# Altium schematic sheet IO-LINK TRANSCEIVER.SchDoc("IO-LINK TRANSCEIVER")
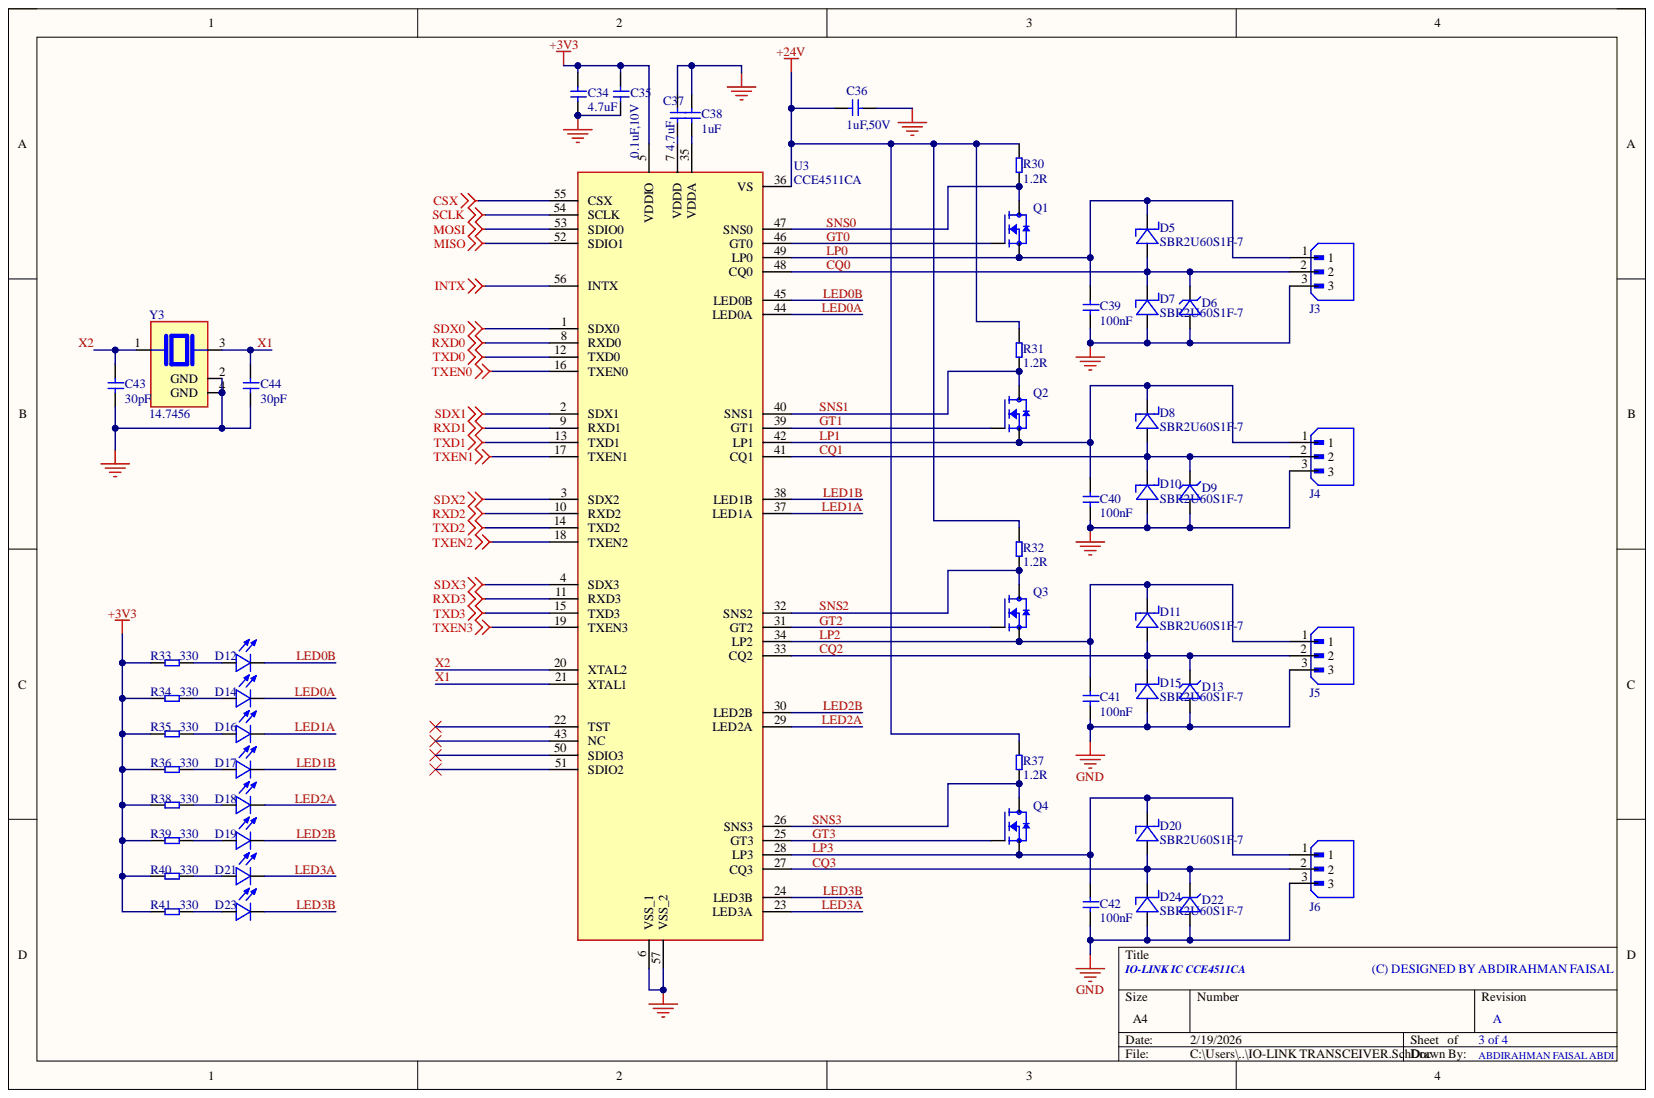
[diagram p0: IO-LINK TRANSCEIVER.SchDoc]

## Parts
C34  4.7uF  [C0603]
C35  0.1uF,10V  [C0603]
C36  1uF,50V  [C0603]
C37  4.7uF  [C0603]
C38  1uF  [C0603]
C39  100nF  [C0603]
C40  100nF  [C0603]
C41  100nF  [C0603]
C42  100nF  [C0603]
C43  30pF  [C0603]
C44  30pF  [C0603]
D5  SBR2U60S1F-7  [SBR2U60S1F-7]
D6  SMF4L33A  [SODFL3718X108N]  (value not drawn)
D7  SBR2U60S1F-7  [SBR2U60S1F-7]
D8  SBR2U60S1F-7  [SBR2U60S1F-7]
D9  SMF4L33A  [SODFL3718X108N]  (value not drawn)
D10  SBR2U60S1F-7  [SBR2U60S1F-7]
D11  SBR2U60S1F-7  [SBR2U60S1F-7]
D12  RED  [LED_VLMS1300-GS08]  (value not drawn)
D13  SMF4L33A  [SODFL3718X108N]  (value not drawn)
D14  RED  [LED_VLMS1300-GS08]  (value not drawn)
D15  SBR2U60S1F-7  [SBR2U60S1F-7]
D16  RED  [LED_VLMS1300-GS08]  (value not drawn)
D17  RED  [LED_VLMS1300-GS08]  (value not drawn)
D18  RED  [LED_VLMS1300-GS08]  (value not drawn)
D19  RED  [LED_VLMS1300-GS08]  (value not drawn)
D20  SBR2U60S1F-7  [SBR2U60S1F-7]
D21  RED  [LED_VLMS1300-GS08]  (value not drawn)
D22  SMF4L33A  [SODFL3718X108N]  (value not drawn)
D23  RED  [LED_VLMS1300-GS08]  (value not drawn)
D24  SBR2U60S1F-7  [SBR2U60S1F-7]
J3  B3B-XH-A(LF)(SN)  [JST_B3B-XH-A]  (value not drawn)
J4  B3B-XH-A(LF)(SN)  [JST_B3B-XH-A]  (value not drawn)
J5  B3B-XH-A(LF)(SN)  [JST_B3B-XH-A]  (value not drawn)
J6  B3B-XH-A(LF)(SN)  [JST_B3B-XH-A]  (value not drawn)
Q1  FQT7N10LTF  [SOT230P700X180-4N]  (value not drawn)
Q2  FQT7N10LTF  [SOT230P700X180-4N]  (value not drawn)
Q3  FQT7N10LTF  [SOT230P700X180-4N]  (value not drawn)
Q4  FQT7N10LTF  [SOT230P700X180-4N]  (value not drawn)
R30  1.2R  [R0805]
R31  1.2R  [R0805]
R32  1.2R  [R0805]
R33  330  [R0603]
R34  330  [R0603]
R35  330  [R0603]
R36  330  [R0603]
R37  1.2R  [R0805]
R38  330  [R0603]
R39  330  [R0603]
R40  330  [R0603]
R41  330  [R0603]
U3  CCE4511CA  [CCE4511CA]
Y3  14.7456  [XTAL_ABM8-14.7456MHz-12-D1X-T]

## Nets
+3V3: C34.2 C35.2 R33.1 R34.1 R35.1 R36.1 R38.1 R39.1 R40.1 R41.1 U3.5(VDDIO)
+24V: C36.2 R30.2 R31.2 R32.2 R37.2 U3.36(VS)
CSX: U3.55(CSX)
GND: C34.1 C35.1 C36.1 C37.2 C38.2 C39.1 C40.1 C41.1 C42.1 C43.1 C44.1 D6.2 D7.2 D9.2 D10.2 D13.2 D15.2 D22.2 D24.2 J3.3 J4.3 J5.3 J6.3 U3.6(VSS_1) U3.57(VSS_2) Y3.2(GND) Y3.4(GND)
INTX: U3.56(INTX)
MISO: U3.52(SDIO1)
MOSI: U3.53(SDIO0)
RXD0: U3.8(RXD0)
RXD1: U3.9(RXD1)
RXD2: U3.10(RXD2)
RXD3: U3.11(RXD3)
SCLK: U3.54(SCLK)
SDX0: U3.1(SDX0)
SDX1: U3.2(SDX1)
SDX2: U3.3(SDX2)
SDX3: U3.4(SDX3)
TXD0: U3.12(TXD0)
TXD1: U3.13(TXD1)
TXD2: U3.14(TXD2)
TXD3: U3.15(TXD3)
TXEN0: U3.16(TXEN0)
TXEN1: U3.17(TXEN1)
TXEN2: U3.18(TXEN2)
TXEN3: U3.19(TXEN3)
CQ0: D5.2 D6.1 D7.1 J3.2 U3.48(CQ0)
CQ1: D8.2 D9.1 D10.1 J4.2 U3.41(CQ1)
CQ2: D11.2 D13.1 D15.1 J5.2 U3.33(CQ2)
CQ3: D20.2 D22.1 D24.1 J6.2 U3.27(CQ3)
GT0: Q1.1 U3.46(GT0)
GT1: Q2.1 U3.39(GT1)
GT2: Q3.1 U3.31(GT2)
GT3: Q4.1 U3.25(GT3)
LED0A: D14.C U3.44(LED0A)
LED0B: D12.C U3.45(LED0B)
LED1A: D16.C U3.37(LED1A)
LED1B: D17.C U3.38(LED1B)
LED2A: D18.C U3.29(LED2A)
LED2B: D19.C U3.30(LED2B)
LED3A: D21.C U3.23(LED3A)
LED3B: D23.C U3.24(LED3B)
LP0: C39.2 D5.1 J3.1 Q1.3 U3.49(LP0)
LP1: C40.2 D8.1 J4.1 Q2.3 U3.42(LP1)
LP2: C41.2 D11.1 J5.1 Q3.3 U3.34(LP2)
LP3: C42.2 D20.1 J6.1 Q4.3 U3.28(LP3)
SNS0: Q1.4 R30.1 U3.47(SNS0)
SNS1: Q2.4 R31.1 U3.40(SNS1)
SNS2: Q3.4 R32.1 U3.32(SNS2)
SNS3: Q4.4 R37.1 U3.26(SNS3)
X1: C44.2 U3.21(XTAL1) Y3.3
X2: C43.2 U3.20(XTAL2) Y3.1
NetC37_1: C37.1 U3.7(VDDD)
NetC38_1: C38.1 U3.35(VDDA)
NetD12_A: D12.A R33.2
NetD14_A: D14.A R34.2
NetD16_A: D16.A R35.2
NetD17_A: D17.A R36.2
NetD18_A: D18.A R38.2
NetD19_A: D19.A R39.2
NetD21_A: D21.A R40.2
NetD23_A: D23.A R41.2

Not connected: U3 22(TST) 43(NC) 50(SDIO3) 51(SDIO2)

## Library data of the parts
C34 C37 = GRM188Z71A475ME15D: 4.7 µF ±20% 10V Ceramic Capacitor X7R 0603 (1608 Metric); Manufacturer: Murata; Manufacturer Lifecycle Status: IN PRODUCTION; Value: 4.7µF; Case/Package: 0603; Category: Ceramic Capacitors; Component Kind: Standard; ComponentLink1Description: https://datasheet.octopart.com:443/GRM188Z71A475ME15D-Murata-datasheet-149523300.pdf?src-supplier=Newark; ComponentLink1URL: https://datasheet.octopart.com:443/GRM188Z71A475ME15D-Murata-datasheet-149523300.pdf?src-supplier=Newark; ComponentLink2Description: https://datasheet.octopart.com:443/GRM188Z71A475ME15D-Murata-datasheet-141276011.pdf?src-supplier=Geoflip; ComponentLink2URL: https://datasheet.octopart.com:443/GRM188Z71A475ME15D-Murata-datasheet-141276011.pdf?src-supplier=Geoflip; ComponentLink3Description: https://datasheet.octopart.com:443/GRM188Z71A475ME15D-Murata-datasheet-165579666.pdf?src-supplier=TME; ComponentLink3URL: https://datasheet.octopart.com:443/GRM188Z71A475ME15D-Murata-datasheet-165579666.pdf?src-supplier=TME; ComponentLink4Description: https://datasheet.octopart.com:443/GRM188Z71A475ME15D-Murata-datasheet-140705914.pdf?src-supplier=Ciiva; ComponentLink4URL: https://datasheet.octopart.com:443/GRM188Z71A475ME15D-Murata-datasheet-140705914.pdf?src-supplier=Ciiva; ComponentLink5Description: https://datasheet.octopart.com:443/GRM188Z71A475ME15D-Murata-datasheet-138743432.pdf?src-supplier=Ciiva; ComponentLink5URL: https://datasheet.octopart.com:443/GRM188Z71A475ME15D-Murata-datasheet-138743432.pdf?src-supplier=Ciiva; ComponentLink6Description: http://sigma.octopart.com:80/reach_statement/Murata-company-16.pdf?src-supplier=Compliance+Statements; ComponentLink6URL: http://sigma.octopart.com:80/reach_statement/Murata-company-16.pdf?src-supplier=Compliance+Statements; Dielectric Material: Ceramic; Height: 800µm; Length: 1.6mm; Lifecycle Status: Production; Manufacturer Part Number: GRM188Z71A475ME15D; Material: Ceramic; Max Operating Temperature: 125°C; Min Operating Temperature: -55°C; Mounting Technology: Surface Mount; Number of Terminals: 2; Pricing: 1=0.21, 10=0.122, 50=0.0874, 100=0.0768, 500=0.05886, 1000=0.05334 (USD); REACH SVHC: Yes; RoHS: Compliant; Stock: 105815; Supplier: DigiKey; Supplier Part Number: 490-GRM188Z71A475ME15DCT-ND; Thickness: 800µm; Tolerance: 20%; Voltage Rating: 10V; Voltage Rating (DC): 10V; Width: 800µm; Library Name: arfaisal.IntLib
C35 = CC0603KRX7R6BB104: 0.1 µF ±10% 10V Ceramic Capacitor X7R 0603; Manufacturer: Yageo Group; Value: 100nF; Case Code (Imperial): 0603; Case Code (Metric): 1608; Case/Package: 0603; Category: Ceramic Capacitors; China RoHS: Compliant; Component Kind: Standard; ComponentLink1Description: https://datasheet.octopart.com:443/CC0603KRX7R6BB104-Yageo-datasheet-177165144.pdf?src-supplier=IHS; ComponentLink1URL: https://datasheet.octopart.com:443/CC0603KRX7R6BB104-Yageo-datasheet-177165144.pdf?src-supplier=IHS; ComponentLink2Description: https://datasheet.octopart.com:443/CC0603KRX7R6BB104-Yageo-datasheet-82288757.pdf?src-supplier=Upverter; ComponentLink2URL: https://datasheet.octopart.com:443/CC0603KRX7R6BB104-Yageo-datasheet-82288757.pdf?src-supplier=Upverter; ComponentLink3Description: https://datasheet.octopart.com:443/CC0603KRX7R6BB104-Yageo-Group-datasheet-189192896.pdf?src-supplier=TME; ComponentLink3URL: https://datasheet.octopart.com:443/CC0603KRX7R6BB104-Yageo-Group-datasheet-189192896.pdf?src-supplier=TME; ComponentLink4Description: https://datasheet.octopart.com:443/CC0603KRX7R6BB104-Yageo-datasheet-182029707.pdf?src-supplier=Farnell; ComponentLink4URL: https://datasheet.octopart.com:443/CC0603KRX7R6BB104-Yageo-datasheet-182029707.pdf?src-supplier=Farnell; ComponentLink5Description: https://datasheet.octopart.com:443/CC0603KRX7R6BB104-Yageo-datasheet-147453679.pdf?src-supplier=Farnell; ComponentLink5URL: https://datasheet.octopart.com:443/CC0603KRX7R6BB104-Yageo-datasheet-147453679.pdf?src-supplier=Farnell; ComponentLink6Description: https://datasheet.octopart.com:443/CC0603KRX7R6BB104-Yageo-datasheet-151948686.pdf?src-supplier=Farnell; ComponentLink6URL: https://datasheet.octopart.com:443/CC0603KRX7R6BB104-Yageo-datasheet-151948686.pdf?src-supplier=Farnell; ComponentLink7Description: https://datasheet.octopart.com/CC0603KRX7R6BB104-Yageo-datasheet-10883670.pdf; ComponentLink7URL: https://datasheet.octopart.com/CC0603KRX7R6BB104-Yageo-datasheet-10883670.pdf; ComponentLink8Description: https://datasheet.octopart.com/CC0603KRX7R6BB104-Yageo-datasheet-12526321.pdf; ComponentLink8URL: https://datasheet.octopart.com/CC0603KRX7R6BB104-Yageo-datasheet-12526321.pdf; ComponentLink9Description: https://datasheet.octopart.com:443/CC0603KRX7R6BB104-Yageo-datasheet-166511530.pdf?src-supplier=Future+Electronics; ComponentLink9URL: https://datasheet.octopart.com:443/CC0603KRX7R6BB104-Yageo-datasheet-166511530.pdf?src-supplier=Future+Electronics; ComponentLink10Description: https://datasheet.octopart.com:443/CC0603KRX7R6BB104-Yageo-datasheet-137700082.pdf?src-supplier=Future+Electronics; ComponentLink10URL: https://datasheet.octopart.com:443/CC0603KRX7R6BB104-Yageo-datasheet-137700082.pdf?src-supplier=Future+Electronics; ComponentLink11Description: https://datasheet.octopart.com:443/CC0603KRX7R6BB104-Yageo-datasheet-137700084.pdf?src-supplier=Future+Electronics; ComponentLink11URL: https://datasheet.octopart.com:443/CC0603KRX7R6BB104-Yageo-datasheet-137700084.pdf?src-supplier=Future+Electronics; ComponentLink12Description: https://datasheet.octopart.com:443/CC0603KRX7R6BB104-Yageo-datasheet-27626582.pdf?src-supplier=Future+Electronics; ComponentLink12URL: https://datasheet.octopart.com:443/CC0603KRX7R6BB104-Yageo-datasheet-27626582.pdf?src-supplier=Future+Electronics; ComponentLink13Description: https://datasheet.octopart.com:443/CC0603KRX7R6BB104-Yageo-datasheet-15895757.pdf?src-supplier=Future+Electronics; ComponentLink13URL: https://datasheet.octopart.com:443/CC0603KRX7R6BB104-Yageo-datasheet-15895757.pdf?src-supplier=Future+Electronics; ComponentLink14Description: https://datasheet.octopart.com:443/CC0603KRX7R6BB104-Yageo-datasheet-10911834.pdf?src-supplier=Future+Electronics; ComponentLink14URL: https://datasheet.octopart.com:443/CC0603KRX7R6BB104-Yageo-datasheet-10911834.pdf?src-supplier=Future+Electronics; ComponentLink15Description: https://datasheet.octopart.com:443/CC0603KRX7R6BB104-Yageo-datasheet-8324442.pdf?src-supplier=Future+Electronics; ComponentLink15URL: https://datasheet.octopart.com:443/CC0603KRX7R6BB104-Yageo-datasheet-8324442.pdf?src-supplier=Future+Electronics; ComponentLink16Description: https://datasheet.octopart.com:443/CC0603KRX7R6BB104-Yageo-Group-datasheet-165992755.pdf?src-supplier=ODG+(Origin+Data+Global); ComponentLink16URL: https://datasheet.octopart.com:443/CC0603KRX7R6BB104-Yageo-Group-datasheet-165992755.pdf?src-supplier=ODG+(Origin+Data+Global); ComponentLink17Description: http://sigma.octopart.com:80/rohs_statement/Surface-Mount-Ceramic-Multilayer-Capacitors%3B-General-Purpose-%26-High-Capacitance-Class-2%2C-X7R-Yageo-family-124.pdf?src-supplier=Compliance+Statements; ComponentLink17URL: http://sigma.octopart.com:80/rohs_statement/Surface-Mount-Ceramic-Multilayer-Capacitors%3B-General-Purpose-%26-High-Capacitance-Class-2%2C-X7R-Yageo-family-124.pdf?src-supplier=Compliance+Statements; ComponentLink18Description: http://sigma.octopart.com:80/reach_statement/Surface-Mount-Ceramic-Multilayer-Capacitors%3B-General-Purpose-%26-High-Capacitance-Class-2%2C-X7R-Yageo-family-125.pdf?src-supplier=Compliance+Statements; ComponentLink18URL: http://sigma.octopart.com:80/reach_statement/Surface-Mount-Ceramic-Multilayer-Capacitors%3B-General-Purpose-%26-High-Capacitance-Class-2%2C-X7R-Yageo-family-125.pdf?src-supplier=Compliance+Statements; ComponentLink19Description: http://sigma.octopart.com:80/rohs_statement/Yageo-company-2.pdf?src-supplier=Compliance+Statements; ComponentLink19URL: http://sigma.octopart.com:80/rohs_statement/Yageo-company-2.pdf?src-supplier=Compliance+Statements; ComponentLink20Description: http://sigma.octopart.com:80/conflict_mineral_statement/Yageo-company-3.pdf?src-supplier=Compliance+Statements; ComponentLink20URL: http://sigma.octopart.com:80/conflict_mineral_statement/Yageo-company-3.pdf?src-supplier=Compliance+Statements; Composition: Ceramic; Depth: 800µm; Dielectric: X7R; Dielectric Material: Ceramic; Halogen Free: Halogen Free; Height: 800µm; Lead Free: Lead Free; Length: 1.6mm; Lifecycle Status: Production; Manufacturer Part Number: CC0603KRX7R6BB104; Material: Ceramic; Max Operating Temperature: 125°C; Min Operating Temperature: -55°C; Mounting Technology: Surface Mount; Number of Terminals: 2; Pricing: 1=0.11, 10=0.04, 50=0.04, 100=0.035, 1000=0.024, 10000=0.017 (USD); Radiation Hardening: No; REACH SVHC: Yes; RoHS: Compliant; Schedule B: 8532240020, 8532240020|8532240020|8532240020|8532240020|8532240020; Stock: 48844; Supplier: Mouser; Supplier Part Number: 603-CC603KRX7R6BB104; Termination: SMD/SMT; Thickness: 889µm; Tolerance: 10%; Voltage Rating: 10V; Voltage Rating (DC): 10V; Weight: 2.012816mg; Width: 800µm; Library Name: arfaisal.IntLib
C36 = CC0603KRX7R9BB105: Ceramic Capacitor, Ceramic, 50V, 10% +Tol, 10% -Tol, X7R, 15% TC, 1uF, Surface Mount, 0603; Manufacturer: Yageo Group; Value: 1µF; Case Code (Imperial): 0603; Case Code (Metric): 1608; Case/Package: 0603; Category: Ceramic Capacitors; China RoHS: Compliant; Component Kind: Standard; ComponentLink1Description: https://datasheet.octopart.com:443/CC0603KRX7R9BB105-Yageo-datasheet-177165144.pdf?src-supplier=IHS; ComponentLink1URL: https://datasheet.octopart.com:443/CC0603KRX7R9BB105-Yageo-datasheet-177165144.pdf?src-supplier=IHS; ComponentLink2Description: https://datasheet.octopart.com:443/CC0603KRX7R9BB105-Yageo-datasheet-82288757.pdf?src-supplier=Upverter; ComponentLink2URL: https://datasheet.octopart.com:443/CC0603KRX7R9BB105-Yageo-datasheet-82288757.pdf?src-supplier=Upverter; ComponentLink3Description: https://datasheet.octopart.com:443/CC0603KRX7R9BB105-Yageo-datasheet-149070022.pdf?src-supplier=Upverter; ComponentLink3URL: https://datasheet.octopart.com:443/CC0603KRX7R9BB105-Yageo-datasheet-149070022.pdf?src-supplier=Upverter; ComponentLink4Description: https://sigma.octopart.com:443/147830939/technical_drawing/Yageo-CC0603KRX7R9BB105.pdf?src-supplier=Upverter; ComponentLink4URL: https://sigma.octopart.com:443/147830939/technical_drawing/Yageo-CC0603KRX7R9BB105.pdf?src-supplier=Upverter; ComponentLink5Description: https://datasheet.octopart.com:443/CC0603KRX7R9BB105-Yageo-datasheet-181968729.pdf?src-supplier=Newark; ComponentLink5URL: https://datasheet.octopart.com:443/CC0603KRX7R9BB105-Yageo-datasheet-181968729.pdf?src-supplier=Newark; ComponentLink6Description: https://datasheet.octopart.com:443/CC0603KRX7R9BB105-Yageo-datasheet-147453679.pdf?src-supplier=Newark; ComponentLink6URL: https://datasheet.octopart.com:443/CC0603KRX7R9BB105-Yageo-datasheet-147453679.pdf?src-supplier=Newark; ComponentLink7Description: https://datasheet.octopart.com:443/CC0603KRX7R9BB105-Yageo-datasheet-181964739.pdf?src-supplier=Farnell; ComponentLink7URL: https://datasheet.octopart.com:443/CC0603KRX7R9BB105-Yageo-datasheet-181964739.pdf?src-supplier=Farnell; ComponentLink8Description: https://datasheet.octopart.com:443/CC0603KRX7R9BB105-Yageo-datasheet-151948686.pdf?src-supplier=TME; ComponentLink8URL: https://datasheet.octopart.com:443/CC0603KRX7R9BB105-Yageo-datasheet-151948686.pdf?src-supplier=TME; ComponentLink9Description: https://datasheet.octopart.com:443/CC0603KRX7R9BB105-Yageo-datasheet-166511530.pdf?src-supplier=Future+Electronics; ComponentLink9URL: https://datasheet.octopart.com:443/CC0603KRX7R9BB105-Yageo-datasheet-166511530.pdf?src-supplier=Future+Electronics; ComponentLink10Description: https://datasheet.octopart.com:443/CC0603KRX7R9BB105-Yageo-datasheet-137700082.pdf?src-supplier=Future+Electronics; ComponentLink10URL: https://datasheet.octopart.com:443/CC0603KRX7R9BB105-Yageo-datasheet-137700082.pdf?src-supplier=Future+Electronics; ComponentLink11Description: https://datasheet.octopart.com:443/CC0603KRX7R9BB105-Yageo-Group-datasheet-165992755.pdf?src-supplier=ODG+(Origin+Data+Global); ComponentLink11URL: https://datasheet.octopart.com:443/CC0603KRX7R9BB105-Yageo-Group-datasheet-165992755.pdf?src-supplier=ODG+(Origin+Data+Global); ComponentLink12Description: https://datasheet.octopart.com/Surface-Mount-Ceramic-Multilayer-Capacitors%3B-General-Purpose-%26-High-Capacitance-Class-2%2C-X7R-Yageo-datasheet-family-114.pdf; ComponentLink12URL: https://datasheet.octopart.com/Surface-Mount-Ceramic-Multilayer-Capacitors%3B-General-Purpose-%26-High-Capacitance-Class-2%2C-X7R-Yageo-datasheet-family-114.pdf; ComponentLink13Description: https://datasheet.octopart.com/CC0603KRX7R9BB105-Yageo-datasheet-10883670.pdf; ComponentLink13URL: https://datasheet.octopart.com/CC0603KRX7R9BB105-Yageo-datasheet-10883670.pdf; ComponentLink14Description: http://sigma.octopart.com:80/rohs_statement/Surface-Mount-Ceramic-Multilayer-Capacitors%3B-General-Purpose-%26-High-Capacitance-Class-2%2C-X7R-Yageo-family-124.pdf?src-supplier=Compliance+Statements; ComponentLink14URL: http://sigma.octopart.com:80/rohs_statement/Surface-Mount-Ceramic-Multilayer-Capacitors%3B-General-Purpose-%26-High-Capacitance-Class-2%2C-X7R-Yageo-family-124.pdf?src-supplier=Compliance+Statements; ComponentLink15Description: http://sigma.octopart.com:80/reach_statement/Surface-Mount-Ceramic-Multilayer-Capacitors%3B-General-Purpose-%26-High-Capacitance-Class-2%2C-X7R-Yageo-family-125.pdf?src-supplier=Compliance+Statements; ComponentLink15URL: http://sigma.octopart.com:80/reach_statement/Surface-Mount-Ceramic-Multilayer-Capacitors%3B-General-Purpose-%26-High-Capacitance-Class-2%2C-X7R-Yageo-family-125.pdf?src-supplier=Compliance+Statements; ComponentLink16Description: http://sigma.octopart.com:80/rohs_statement/Yageo-company-2.pdf?src-supplier=Compliance+Statements; ComponentLink16URL: http://sigma.octopart.com:80/rohs_statement/Yageo-company-2.pdf?src-supplier=Compliance+Statements; ComponentLink17Description: http://sigma.octopart.com:80/conflict_mineral_statement/Yageo-company-3.pdf?src-supplier=Compliance+Statements; ComponentLink17URL: http://sigma.octopart.com:80/conflict_mineral_statement/Yageo-company-3.pdf?src-supplier=Compliance+Statements; Composition: Ceramic; Dielectric: X7R; Dielectric Material: Ceramic; Halogen Free: Halogen Free; Height: 800µm; Lead Free: Lead Free; Length: 1.6mm; Lifecycle Status: Production; Manufacturer Part Number: CC0603KRX7R9BB105; Material: Ceramic; Max Operating Temperature: 125°C; Min Operating Temperature: -55°C; Mounting Technology: Surface Mount; Number of Terminals: 2; Packaging: Tape & Reel (TR); Pricing: 1=0.47, 10=0.284, 50=0.2124, 100=0.1889, 500=0.15282, 1000=0.14128 (USD); REACH SVHC: Yes; RoHS: Compliant; Schedule B: 8532240020, 8532240020|8532240020|8532240020|8532240020|8532240020; Stock: 109937; Supplier: DigiKey; Supplier Part Number: 311-2082-1-ND; Termination: SMD/SMT; Thickness: 889µm; Tolerance: 10%; Voltage Rating: 50V; Voltage Rating (DC): 50V; Weight: 2.012816mg; Width: 800µm; Library Name: arfaisal.IntLib
C38 = CL10B105KP8NNNC: CAP CER 1UF 10V X7R 0603; Manufacturer: Samsung; Tolerance: 10%; Capacitance: 1uF; Case Code (Imperial): 0603; Case Code (Metric): 1608; Case/Package: 0603; Category: Ceramic Capacitors; Component Kind: Standard; ComponentLink1Description: https://datasheet.ciiva.com/25788/cl10b105kp8nnnc-25788304.pdf?src-supplier=Digikey; ComponentLink1URL: https://datasheet.ciiva.com/25788/cl10b105kp8nnnc-25788304.pdf?src-supplier=Digikey; ComponentLink2Description: https://datasheet.ciiva.com/16396/cl10b105kp8nnnc-16396970.pdf?src-supplier=Future+Electronics; ComponentLink2URL: https://datasheet.ciiva.com/16396/cl10b105kp8nnnc-16396970.pdf?src-supplier=Future+Electronics; ComponentLink3Description: https://datasheet.ciiva.com/26838/getdatasheetpartid-358410-26838426.pdf?src-supplier=Verical; ComponentLink3URL: https://datasheet.ciiva.com/26838/getdatasheetpartid-358410-26838426.pdf?src-supplier=Verical; Construction: Flat; Depth: 0.8mm; Dielectric: X7R; Height: 0.8mm; LCSC part number: C95843; Lead Free: Lead Free; Length: 1.6mm; Manufacturer Part Number: CL10B105KP8NNNC; Max Operating Temperature: 125°C; Min Operating Temperature: -55°C; Mount: Surface Mount; Package Quantity: 1; Packaging: Tape and Reel; Pricing 1: 1=0.08, 10=0.005 (USD); Radiation Hardening: No; RoHS: Yes; RoHS Compliant: Yes; Series: CL; Stock 1: 5099033; Supplier 1: Digikey; Thickness: 0.035inch; Voltage Rating: 10V; Voltage Rating (DC): 10V; Width: 0.031inch; Library Name: arfaisal.IntLib
C39-C42 = CGA3E3X8R1H104K080AD: CAP CER 0.1UF 50V X8R 0603 EPOXY; Manufacturer: TDK; Category: Ceramic Capacitors; Component Kind: Standard; ComponentLink1Description: https://datasheet.ciiva.com/24219/cga3e3x8r1h104k080ad-270768-24219691.pdf?src-supplier=Mouser; ComponentLink1URL: https://datasheet.ciiva.com/24219/cga3e3x8r1h104k080ad-270768-24219691.pdf?src-supplier=Mouser; LCSC part number: C2171928; Manufacturer Part Number: CGA3E3X8R1H104K080AD; Pricing 1: 1=0.22, 10=0.129, 50=0.0922, 100=0.0693, 500=0.06232, 1000=0.05656 (USD); RoHS: Yes; Stock 1: 30979; Supplier 1: Digikey; Library Name: arfaisal.IntLib
C43 C44 = AC0603JRNPO9BN300: CAP CER 30PF 50V C0G/NPO 0603; Manufacturer: Yageo; Category: Ceramic Capacitors; Component Kind: Standard; LCSC part number: C2191217; Library Name: IO.SchLib; Manufacturer Part Number: AC0603JRNPO9BN300; Pricing 1: 1=0.11, 10=0.061, 50=0.0424, 100=0.035, 500=0.02706, 1000=0.02316 (USD); RoHS: No; Stock 1: 2686; Supplier 1: Digikey
D5 D7 D8 D10 D11 D15 D20 D24 = SBR2U60S1F-7: Transil Diode (TVS); 2000W; 33V; 7.5A; unidirectional; -55+150 deg.C; SMD; SOD123FL; Manufacturer: Diodes Inc.; Average Rectified Current: 2A; Breakdown Voltage: 38.65V; Clamping Voltage: 53.3V; Forward Voltage: 510mV; Max Breakdown Voltage: 40.6V; Max Forward Surge Current (Ifsm): 35A; Max Power Dissipation: 1W; Max Reverse Leakage Current: 1µA; Max Reverse Voltage (DC): 60V; Min Breakdown Voltage: 36.7V; Number of Elements: 1; Peak Pulse Power: 2kW; Peak Reverse Current: 150µA; Test Current: 1mA; Category: Rectifier Diodes; China RoHS: Non-Compliant; Component Kind: Standard; ComponentLink1Description: https://datasheet.octopart.com:443/SBR2U60S1F-7-Diodes-Inc.-datasheet-180563099.pdf?src-supplier=Newark; ComponentLink1URL: https://datasheet.octopart.com:443/SBR2U60S1F-7-Diodes-Inc.-datasheet-180563099.pdf?src-supplier=Newark; ComponentLink2Description: https://datasheet.octopart.com:443/SBR2U60S1F-7-Diodes-Inc.-datasheet-101746258.pdf?src-supplier=Diodes+Inc+SCT; ComponentLink2URL: https://datasheet.octopart.com:443/SBR2U60S1F-7-Diodes-Inc.-datasheet-101746258.pdf?src-supplier=Diodes+Inc+SCT; ComponentLink3Description: https://sigma.octopart.com:443/138896255/technical_drawing/Diodes-Inc.-SBR2U60S1F-7.pdf?src-supplier=Diodes+Inc+SCT; ComponentLink3URL: https://sigma.octopart.com:443/138896255/technical_drawing/Diodes-Inc.-SBR2U60S1F-7.pdf?src-supplier=Diodes+Inc+SCT; ComponentLink4Description: https://datasheet.octopart.com:443/SMF4L33A-Littelfuse-datasheet-170667354.pdf?src-supplier=IHS; ComponentLink4URL: https://datasheet.octopart.com:443/SMF4L33A-Littelfuse-datasheet-170667354.pdf?src-supplier=IHS; ComponentLink5Description: https://datasheet.octopart.com:443/SMF4L33A-Littelfuse-datasheet-86810263.pdf?src-supplier=Newark; ComponentLink5URL: https://datasheet.octopart.com:443/SMF4L33A-Littelfuse-datasheet-86810263.pdf?src-supplier=Newark; ComponentLink6Description: https://datasheet.octopart.com:443/SMF4L33A-Littelfuse-datasheet-136158721.pdf?src-supplier=Future+Electronics; ComponentLink6URL: https://datasheet.octopart.com:443/SMF4L33A-Littelfuse-datasheet-136158721.pdf?src-supplier=Future+Electronics; ComponentLink7Description: https://datasheet.octopart.com:443/SMF4L33A-Littelfuse-datasheet-170905090.pdf?src-supplier=Future+Electronics; ComponentLink7URL: https://datasheet.octopart.com:443/SMF4L33A-Littelfuse-datasheet-170905090.pdf?src-supplier=Future+Electronics; ComponentLink8Description: https://datasheet.octopart.com:443/SMF4L33A-Littelfuse-datasheet-159141417.pdf?src-supplier=TME; ComponentLink8URL: https://datasheet.octopart.com:443/SMF4L33A-Littelfuse-datasheet-159141417.pdf?src-supplier=TME; Lifecycle Status: Production; Manufacturer Part Number: SBR2U60S1F-7; Max Operating Temperature: 175°C; Max Output current: 2A; Min Operating Temperature: -55°C; Mounting Technology: Surface Mount; Number of Terminals: 2; Packaging: Cut Tape; Peak Pulse Current: 7.5A; Polarity: Unidirectional; Pricing: 3000=0.0831, 6000=0.0817, 12000=0.0804, 15000=0.0799, 45000=0.0773 (USD); REACH SVHC: No; Reverse Standoff Voltage: 60V; Reverse Voltage: 33V; RoHS: Compliant; Schedule B: 8541100080, 8541100080|8541100080|8541100080|8541100080|8541100080; Stock: 855000; Supplier: Future Electronics; Supplier Part Number: 7203679; Library Name: ARFEISAL.SchLib
D6 D9 D13 D22 = SMF4L33A: TVS DIODE 33VWM 53.3VC SOD123F; Component Kind: Standard; Library Name: arfaisal.IntLib
D12 D14 D16-D19 D21 D23 = VLMS1300-GS08: LED RED 0603 SMD; Manufacturer: Vishay Semiconductors; Dominant Wavelength: 631nm; Forward Current: 20mA; Forward Voltage: 2V; Lens Style: Rectangular,Clear; Luminous Intensity: 54mcd; Number of LEDs: 1; Power Rating: 75mW; Viewing Angle: 130°; Case Code (Imperial): 0603; Case Code (Metric): 1608; Case/Package: 0603; Category: LEDs; Color: Red; Component Kind: Standard; ComponentLink1Description: https://datasheet.ciiva.com/26322/vlmo1300-26322153.pdf?src-supplier=Element14; ComponentLink1URL: https://datasheet.ciiva.com/26322/vlmo1300-26322153.pdf?src-supplier=Element14; ComponentLink2Description: https://datasheet.ciiva.com/8028/vlmo1300-8028047.pdf?src-supplier=Element14; ComponentLink2URL: https://datasheet.ciiva.com/8028/vlmo1300-8028047.pdf?src-supplier=Element14; ComponentLink3Description: https://datasheet.ciiva.com/9333/vlmo1300-9333743.pdf?src-supplier=Avnet; ComponentLink3URL: https://datasheet.ciiva.com/9333/vlmo1300-9333743.pdf?src-supplier=Avnet; ComponentLink4Description: https://datasheet.ciiva.com/16510/vlmo1300-16510985.pdf?src-supplier=Future+Electronics; ComponentLink4URL: https://datasheet.ciiva.com/16510/vlmo1300-16510985.pdf?src-supplier=Future+Electronics; Height: 0.8mm; Illumination Color: Red; LCSC part number: C83242; Lead Free: Lead Free; Length: 1.6mm; Manufacturer Part Number: VLMS1300-GS08; Max Operating Temperature: 85°C; Min Operating Temperature: -35°C; Mount: Surface Mount; Package Quantity: 1; Packaging: Tape and Reel; Pricing 1: 1=0.21, 10=0.143, 100=0.0998, 500=0.07982, 1000=0.07322 (USD); Radiation Hardening: No; Reach SVHC Compliant: Unknown; RoHS: Yes; RoHS Compliant: Yes; Series: CHipLED; Stock 1: 42495; Supplier 1: Digikey; Test Current: 20mA; Wavelength: 631nm; Width: 0.8mm; Library Name: arfaisal.IntLib
J3-J6 = B3B-XH-A(LF)(SN): CONN HEADER VERT 2POS 2.5MM; Manufacturer: JST; Contact Resistance: 20mR; Current Rating: 3A; Gender: Male; Insulation Resistance: 1GR; Max Voltage Rating (AC): 250V; Number of Contacts: 3; Wire/Cable Gauge: 22AWG; Category: Headers and Wire Housings; Color: Natural; Component Kind: Standard; ComponentLink1Description: https://datasheet.ciiva.com/11746/0900766b8082653f-11746520.pdf?src-supplier=Rs; ComponentLink1URL: https://datasheet.ciiva.com/11746/0900766b8082653f-11746520.pdf?src-supplier=Rs; ComponentLink2Description: https://datasheet.ciiva.com/26894/getdatasheetpartid-640434-26894454.pdf?src-supplier=Verical; ComponentLink2URL: https://datasheet.ciiva.com/26894/getdatasheetpartid-640434-26894454.pdf?src-supplier=Verical; ComponentLink3Description: https://datasheet.ciiva.com/26894/getdatasheetpartid-440399-26894778.pdf?src-supplier=Verical; ComponentLink3URL: https://datasheet.ciiva.com/26894/getdatasheetpartid-440399-26894778.pdf?src-supplier=Verical; Connector Type: Header; Contact Finish - Mating: TIN; Contact Material: Brass; Contact Plating: Tin,Lead,Copper; Contact Shape: Square; Contact Type: Male Pin; Depth: 5.75mm; Fastening Type: Detent; Flammability Rating: UL94 V-0; Housing Color: White; Housing Material: Polyamide; Insulation Color: Natural; Insulation Material: Nylon; LCSC part number: C144394; Lead Free: Lead Free; Lead Pitch: 2.5mm; Length: 9.9mm; Manufacturer Part Number: B3B-XH-A(LF)(SN); Max Current Rating: 3A; Max Operating Temperature: 85°C; Max Voltage Rating (DC): 250V; Min Operating Temperature: -25°C; Mount: Through Hole; Number of Positions: 3; Number of Rows: 1; Orientation: Straight; Packaging: Bulk; Pitch: 2.5mm; Pricing 1: 1=0.07, 400=0.068, 1250=0.0661, 4000=0.0643, 12500=0.0607 (USD); Reach SVHC Compliant: No; RoHS: Yes; Series: XH; Stock 1: 87879; Supplier 1: Future Electronics; Termination: Solder; Voltage Rating: 250V; Voltage Rating (AC): 250V; Weight: 28.349523g; Library Name: arfaisal.IntLib
Q1-Q4 = FQT7N10LTF: MOSFET N-CH 100V 1.7A SOT223-4; Manufacturer: onsemi; Drain to Source Resistance: 350mR; Element Configuration: Single; Fall Time: 50ns; Gate to Source Voltage (Vgs): 20V; Input Capacitance: 290pF; Max Power Dissipation: 2W; Number of Channels: 1; Polarity: N-CHANNEL; Rise Time: 100ns; Threshold Voltage: 2V; Turn-Off Delay Time: 17ns; Turn-On Delay Time: 9ns; Case/Package: SOT-223; Component Kind: Standard; ComponentLink1Description: https://datasheet.ciiva.com/4442/fqt7n10l-112492-4442638.pdf?src-supplier=Mouser; ComponentLink1URL: https://datasheet.ciiva.com/4442/fqt7n10l-112492-4442638.pdf?src-supplier=Mouser; ComponentLink2Description: https://datasheet.ciiva.com/27051/933775-27051022.pdf?src-supplier=Jameco; ComponentLink2URL: https://datasheet.ciiva.com/27051/933775-27051022.pdf?src-supplier=Jameco; ComponentLink3Description: https://datasheet.ciiva.com/8114/fqt7n10l-8114544.pdf?src-supplier=Avnet; ComponentLink3URL: https://datasheet.ciiva.com/8114/fqt7n10l-8114544.pdf?src-supplier=Avnet; ComponentLink4Description: https://datasheet.ciiva.com/15137/getdatasheetpartid-60091-15137237.pdf?src-supplier=Verical; ComponentLink4URL: https://datasheet.ciiva.com/15137/getdatasheetpartid-60091-15137237.pdf?src-supplier=Verical; Continuous Drain Current (ID): 1.7A; Current Rating: 1.7A; Drain to Source Breakdown Voltage: 100V; Drain to Source Voltage (Vdss): 100V; Drain-source On Resistance-Max: 350mR; Height: 1.6mm; LCSC part number: C154506; Lead Free: Lead Free; Length: 6.5mm; Manufacturer Part Number: FQT7N10LTF; Max Operating Temperature: 150°C; Min Operating Temperature: -55°C; Mount: Surface Mount; Number of Elements: 1; Number of Pins: 4; Package Quantity: 1; Packaging: Tape and Reel; Power Dissipation: 2W; Pricing 1: 1=0.97, 10=0.657, 100=0.4355, 500=0.3559, 1000=0.32249, 2000=0.29438 (USD); Radiation Hardening: No; Rds On Max: 350mR; Reach SVHC Compliant: No; RoHS: Yes; RoHS Compliant: Yes; Series: QFET™; Stock 1: 28778; Supplier 1: Digikey; Voltage Rating (DC): 100V; Weight: 0.188g; Width: 3.56mm; Library Name: arfaisal.IntLib
R30-R32 R37 = CRCW08051R20FKEA: Res Thick Film 0805 1.2 Ohm 1% 1/8W ±100ppm/°C Molded SMD SMD Paper T/R; Manufacturer: Vishay; Manufacturer Package Identifier: CRCW0805-e3; Max Power Dissipation: 125mW; Value: 1.2Ω; Voltage Rating: 150V; Case Code (Imperial): 0805; Case Code (Metric): 2012; Case/Package: 0805; Category: Chip SMD Resistors; China RoHS: Non-Compliant; Component Kind: Standard; ComponentLink1Description: https://datasheet.octopart.com:443/CRCW08051R20FKEA-Vishay-datasheet-175423584.pdf?src-supplier=IHS; ComponentLink1URL: https://datasheet.octopart.com:443/CRCW08051R20FKEA-Vishay-datasheet-175423584.pdf?src-supplier=IHS; ComponentLink2Description: https://datasheet.octopart.com:443/CRCW08051R20FKEA-Vishay-datasheet-62316122.pdf?src-supplier=Future+Electronics; ComponentLink2URL: https://datasheet.octopart.com:443/CRCW08051R20FKEA-Vishay-datasheet-62316122.pdf?src-supplier=Future+Electronics; ComponentLink3Description: http://sigma.octopart.com:80/rohs_statement/Vishay-company-15.pdf?src-supplier=Compliance+Statements; ComponentLink3URL: http://sigma.octopart.com:80/rohs_statement/Vishay-company-15.pdf?src-supplier=Compliance+Statements; Composition: Thick Film; Contact Plating: Tin; Depth: 1.25mm; Features: Automotive AEC-Q200; Height: 450µm; Lead Free: Lead Free; Length: 2.0066mm; Lifecycle Status: Production; Manufacturer Part Number: CRCW08051R20FKEA; Max Operating Temperature: 155°C; Military Standard: Not; Min Operating Temperature: -55°C; Mounting Technology: Surface Mount; Number of Terminals: 2; Number of Terminations: 2; Packaging: Digi-Reel®; Power Dissipation: 125mW; Power Rating: 125mW; Pricing: 1=0.11, 10=0.05, 25=0.0388, 50=0.032, 100=0.0268, 250=0.0216, 500=0.01862, 1000=0.01628 (USD); Radiation Hardening: No; REACH SVHC: Yes; RoHS: Compliant; Stock: 22251; Supplier: DigiKey; Supplier Part Number: 541-1.20CCCT-ND; Temperature Coefficient: 100ppm/°C; Tolerance: 1%; Width: 1.25mm; Working Voltage: 150V; Library Name: arfaisal.IntLib
R33-R36 R38-R41 = RC0603FR-07330RL: RES 330 OHM 1% 1/10W 0603; Manufacturer: Yageo; Capacitance: 47uF; ESR (Equivalent Series Resistance): 800mR; Max Power Dissipation: 100mW; Resistance: 330R; Temperature Coefficient: 100ppm/°C; Voltage Rating: 50V; Case Code (Imperial): 0603; Case Code (Metric): 1608; Case/Package: 0603; Category: Chip SMD Resistors; Component Kind: Standard; Depth: 0.8mm; Features: Moisture Resistant; Height: 0.45mm; LCSC part number: C105881; Lead Free: 5697LeadFree; Length: 1.6mm; Manufacturer Part Number: RC0603FR-07330RL; Max Operating Temperature: 155°C; Min Operating Temperature: -55°C; Number of Pins: 2; Number of Terminations: 2; Package Quantity: 1; Packaging: Tape and Reel; Power Rating: 100mW; Pricing 1: 1=0.1, 10=0.009, 100=0.0082, 1000=0.00612, 2500=0.00461 (USD); Reach SVHC Compliant: No; Resistance Tolerance: 1%; Resistor Type: Thick Film; RoHS: Yes; RoHS Compliant: Yes; Series: RC; Size Code: 0603; Stock 1: 425796; Supplier 1: Digikey; Technology: THICK FILM; Termination: SMD/SMT; Tolerance: 1%; Voltage Rating (AC): 50V; Voltage Rating (DC): 50V; Width: 0.8mm; Library Name: arfaisal.IntLib
U3 = CCE4511CA: 4-Ch IO-Link Master with 0.5A; Manufacturer: Renesas; Manufacturer Lifecycle Status: ACTIVE; Max Supply Voltage: 36V; Min Supply Voltage: 8V; Number of Circuits: 1; Operating Supply Voltage: 24V; Pitch: 500µm; Category: Integrated Circuits (ICs); Component Kind: Standard; ComponentLink1Description: https://datasheet.octopart.com:443/CCE4511CA-Renesas-datasheet-182586920.pdf?src-supplier=Renesas; ComponentLink1URL: https://datasheet.octopart.com:443/CCE4511CA-Renesas-datasheet-182586920.pdf?src-supplier=Renesas; ComponentLink2Description: http://sigma.octopart.com:80/conflict_mineral_statement/Renesas-company-44.pdf?src-supplier=Compliance+Statements; ComponentLink2URL: http://sigma.octopart.com:80/conflict_mineral_statement/Renesas-company-44.pdf?src-supplier=Compliance+Statements; ComponentLink3Description: http://sigma.octopart.com:80/reach_statement/Renesas-company-45.pdf?src-supplier=Compliance+Statements; ComponentLink3URL: http://sigma.octopart.com:80/reach_statement/Renesas-company-45.pdf?src-supplier=Compliance+Statements; Export Control Classification Number (ECCN) Code: EAR99; Harmonized Tariff Schedule (HTS) Code: 8542 39 90; Height - Seated (Max): 900µm; Length: 8mm; Lifecycle Status: Production; Manufacturer Part Number: CCE4511CA; Max Operating Temperature: 125°C; Min Operating Temperature: -40°C; MSL: 3; Number of Terminals: 56; Package Quantity: 3000; Packaging: Tape & Reel; Part Family: CCE4511; Pins: 56; Pricing: 1=8.61, 10=6.685, 25=6.2036, 100=5.6742, 250=5.42184, 500=5.2697, 1000=5.14452 (USD); Stock: 1649; Supplier: DigiKey; Supplier Part Number: 1695-CCE4511CACT-ND; Terminal Pitch: 500µm; Width: 8mm; Library Name: ARFEISAL.SchLib
Y3 = ABM8-14.7456MHZ-12-D1X-T: CRYSTAL 14.7456MHZ 12PF SMD; Manufacturer: Abracon; ESR: 120Ω; Frequency Stability: 20ppm; Frequency Tolerance: 0.001%; Category: Crystals; China RoHS: Compliant; Component Kind: Standard; ComponentLink1Description: https://datasheet.octopart.com:443/ABM8-14.7456MHZ-12-D1X-T-Abracon-datasheet-103059652.pdf?src-supplier=IHS; ComponentLink1URL: https://datasheet.octopart.com:443/ABM8-14.7456MHZ-12-D1X-T-Abracon-datasheet-103059652.pdf?src-supplier=IHS; ComponentLink2Description: https://datasheet.octopart.com:443/ABM8-14.7456MHZ-12-D1X-T-Abracon-datasheet-154833172.pdf?src-supplier=Master+Electronics; ComponentLink2URL: https://datasheet.octopart.com:443/ABM8-14.7456MHZ-12-D1X-T-Abracon-datasheet-154833172.pdf?src-supplier=Master+Electronics; ComponentLink3Description: https://sigma.octopart.com:443/141159868/technical_drawing/Abracon-ABM8-14.7456MHZ-12-D1X-T.pdf?src-supplier=Abracon+SCT; ComponentLink3URL: https://sigma.octopart.com:443/141159868/technical_drawing/Abracon-ABM8-14.7456MHZ-12-D1X-T.pdf?src-supplier=Abracon+SCT; ComponentLink4Description: http://sigma.octopart.com:80/conflict_mineral_statement/Abracon-company-27.pdf?src-supplier=Compliance+Statements; ComponentLink4URL: http://sigma.octopart.com:80/conflict_mineral_statement/Abracon-company-27.pdf?src-supplier=Compliance+Statements; ESR (Equivalent Series Resistance): 120Ω; Frequency: 14.7456MHz; Height: 800µm; Length: 3.2mm; Lifecycle Status: Production; Load Capacitance: 12pF; Manufacturer Part Number: ABM8-14.7456MHZ-12-D1X-T; Max Operating Temperature: 85°C; Min Operating Temperature: -40°C; Mounting Technology: Surface Mount; Pricing: 1=0.43, 10=0.375, 25=0.3544, 50=0.3398, 100=0.3257, 250=0.30804, 500=0.29528 (USD); REACH SVHC: Yes; RoHS: Compliant; Schedule B: 8541600080; Stock: 7042; Supplier: DigiKey; Supplier Part Number: 535-14966-1-ND; Tolerance: 0.001%; Width: 2.5mm; Library Name: arfaisal.IntLib
